# Altium schematic sheet Schematics.SchDoc
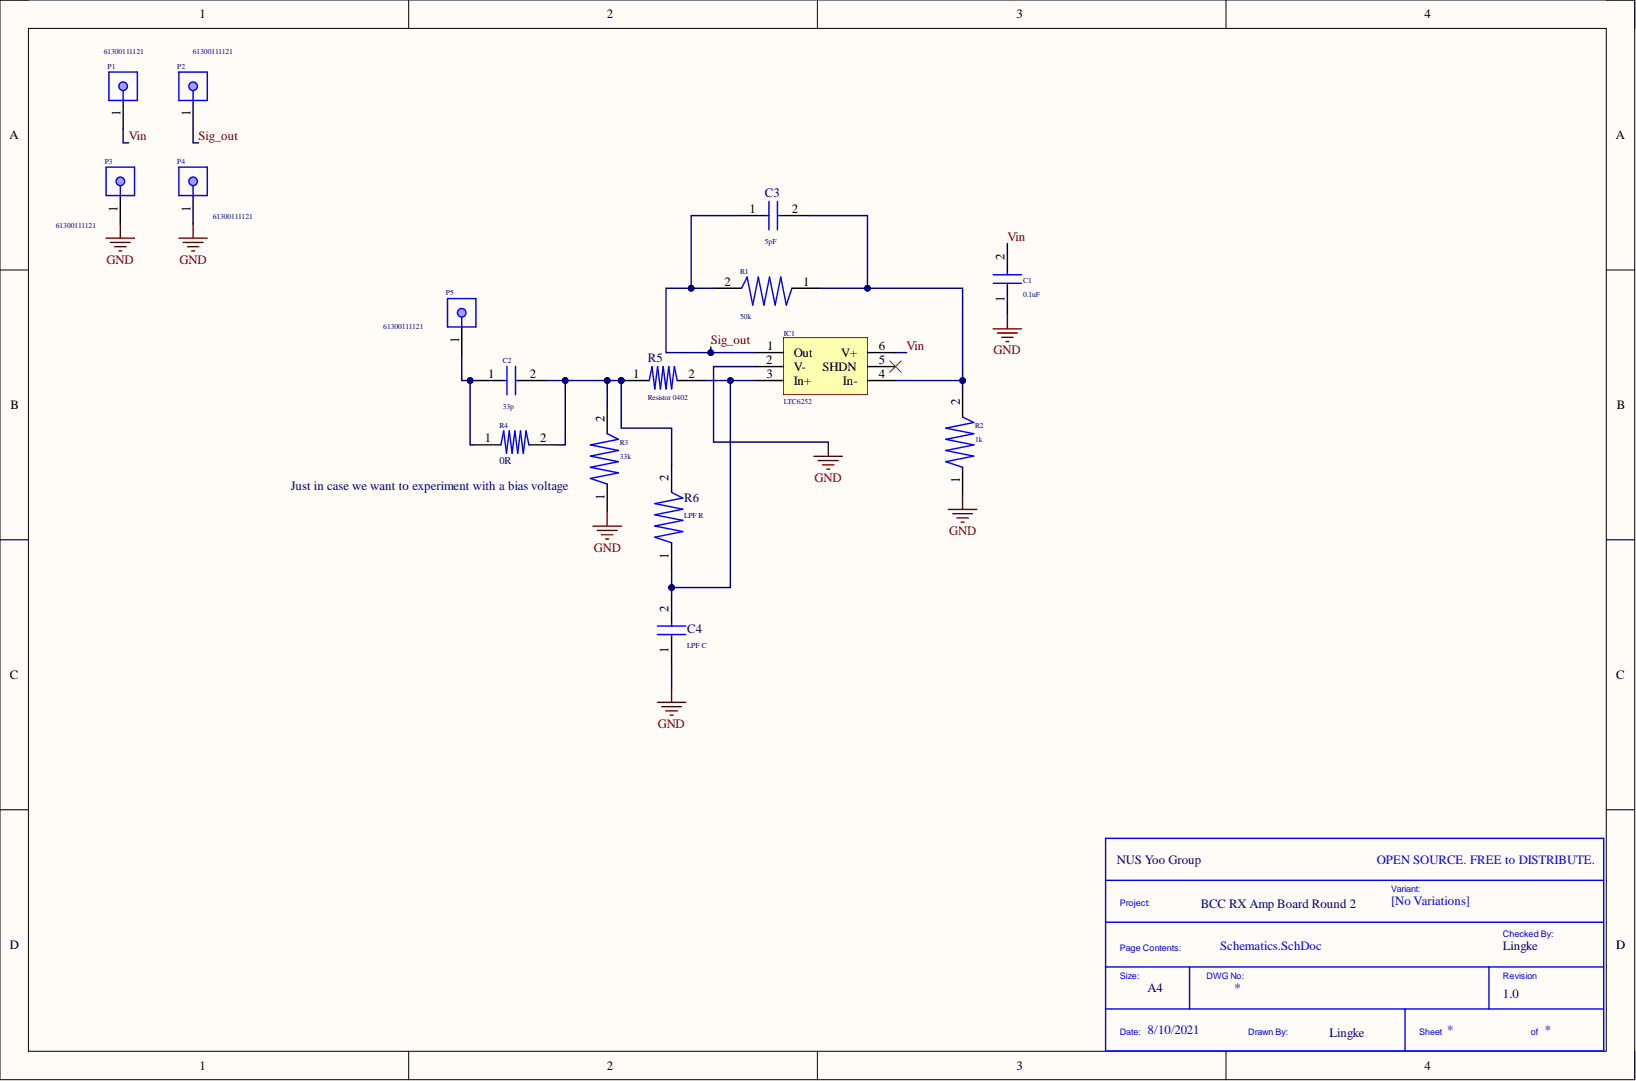
[diagram p0: Schematics.SchDoc]

## Parts
*?  Checked By: Lingke  Company: NUS Yoo Group  Project Name: BCC RX Amp Board Round 2  Revision: 1.0  Size: A4  (hidden comment: Title_Block)  (no BOM)
C1  0.1uF  [0603]
C2  33p  [0603]
C3  5pF  [0603]
C4  LPF C  [0603]
IC1  LTC6252  [SOT-23-6]
P1  61300111121  [61300111121]
P2  61300111121  [61300111121]
P3  61300111121  [61300111121]
P4  61300111121  [61300111121]
P5  61300111121  [61300111121]
R1  50k  [0603]
R2  1k  [0603]
R3  33k  [0603]
R4  0R  [0402]
R5  Resistor 0402  [0402]
R6  LPF R  [0603]

## Nets
GND: C1.1 C4.1 IC1.2(V-) P3.1 P4.1 R2.1 R3.1
Sig_out: C3.1 IC1.1(Out) P2.1 R1.2
Vin: C1.2 IC1.6(V+) P1.1
NetC2_1: C2.1 P5.1 R4.1
NetC2_2: C2.2 R3.2 R4.2 R5.1 R6.2
NetC3_2: C3.2 IC1.4(In-) R1.1 R2.2
NetC4_2: C4.2 IC1.3(In+) R5.2 R6.1

Not connected: IC1 5(SHDN)

## Library data of the parts
*? = Title_Block: Standard Title Block.; Drawn By: Lingke; Library Name: WPT Round 2 TX.IntLib
C1-C4 = Capacitor: Component Kind: Standard; Description: Capacitor
  C1 C2: Library Name: Symbol_lib.SchLib
  C3 C4: Library Name: Symbol_library.SchLib
P1-P5 = 61300111121: WR-PHD Pin Header, THT, pitch 2.54mm, Single Row, Vertical, 1p; Manufacturer: Wurth Elektronik; Contact Resistance: 20mOhm; Pin Number: 1; Rated Current: 3A; Withstanding Voltage: 500VAC; Working Voltage: 250VAC; Component Kind: Standard; ComponentLink1Description: Manufacturer; ComponentLink1URL: http://www.we-online.de; ComponentLink2Description: Datasheet; ComponentLink2URL: https://www.we-online.com/catalog/datasheet/61300111121.pdf; Gender: Pin Header; Library Name: Altium_WR-PHD (rev20a).IntLib; Manufacturer Part Number: 61300111121; Mounting Technology: THT; Operating Temperature: -40 to +105degC; Orientation: Vertical; Packaging: Bag; Pitch: 2.54mm; Published Date: May 2020; Row Number: Single
R1-R3 R6 = Resistor 0603: Resistor; Component Kind: Standard
  R1-R3: Library Name: Symbol_lib.SchLib
  R6: Library Name: Symbol_library.SchLib
R4 = Resistor 0402: Component Kind: Standard; Library Name: Symbol_library.SchLib
IC1 = LTC6252: Component Kind: Standard; Library Name: Symbol_library.SchLib; Supplier 1: Mouser; Supplier Part Number 1: 584-LTC6252CS6TRMPBF
R5 = Resistor 0402: Component Kind: Standard; Library Name: Symbol_library.SchLib
